# Altium schematic sheet Synth.SchDoc
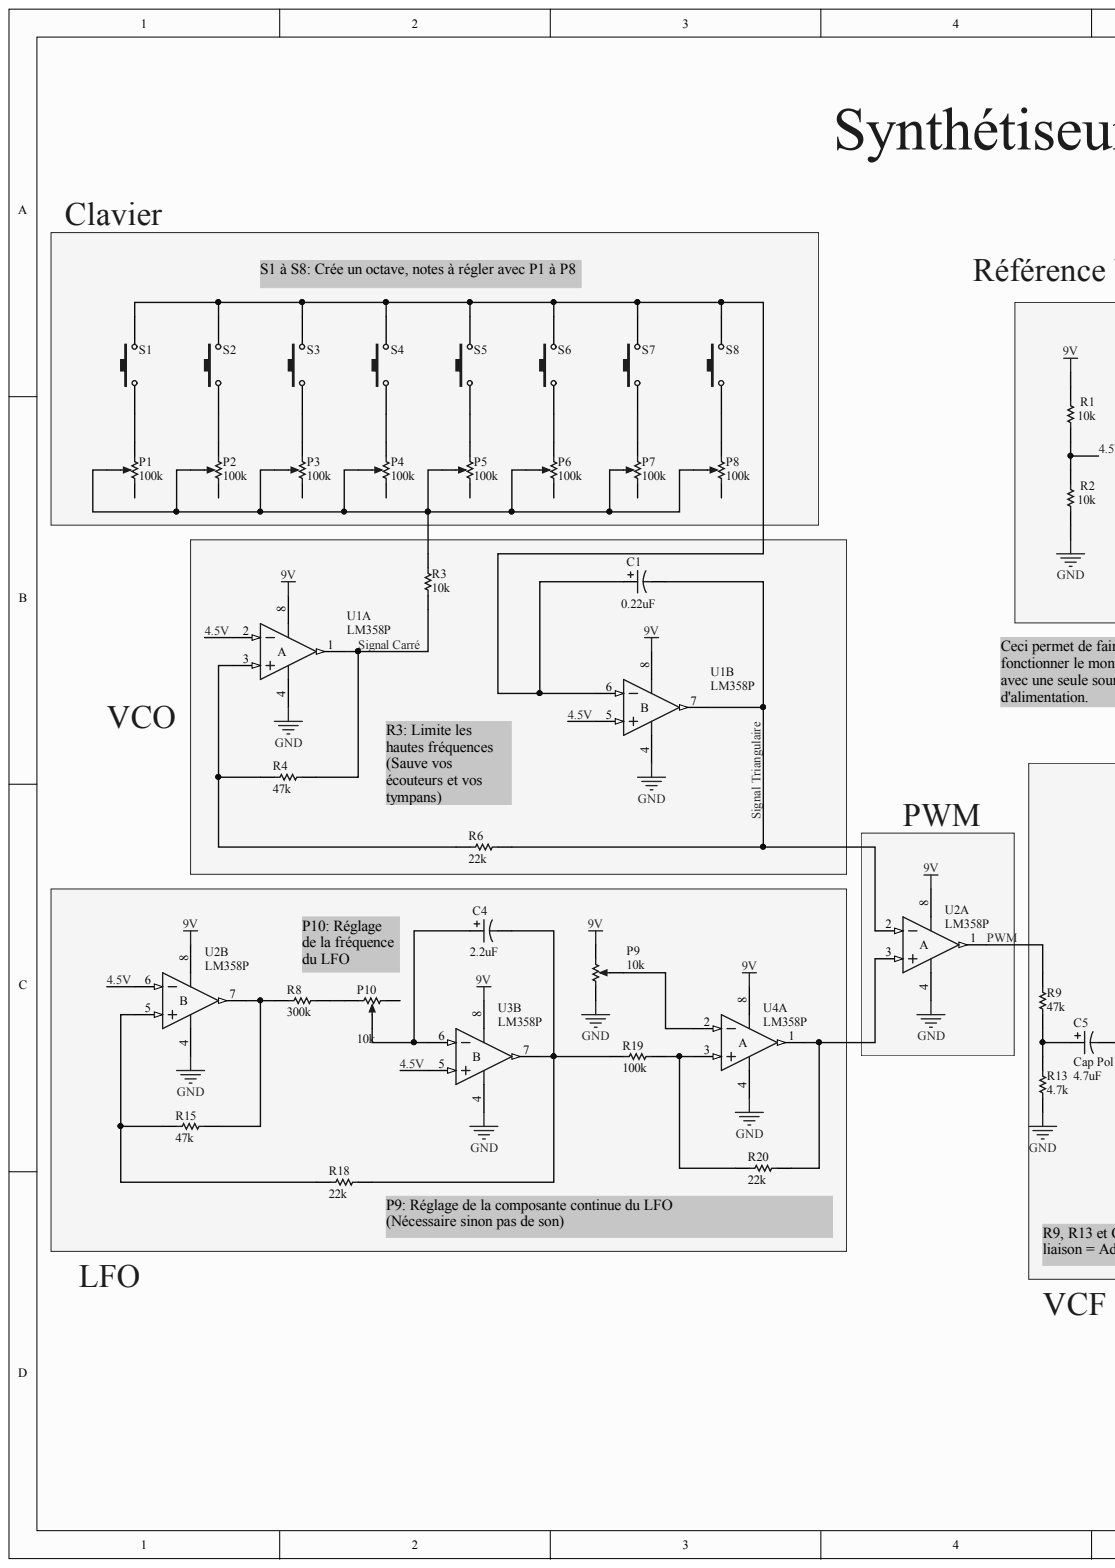
[diagram p0: Synth.SchDoc, left]
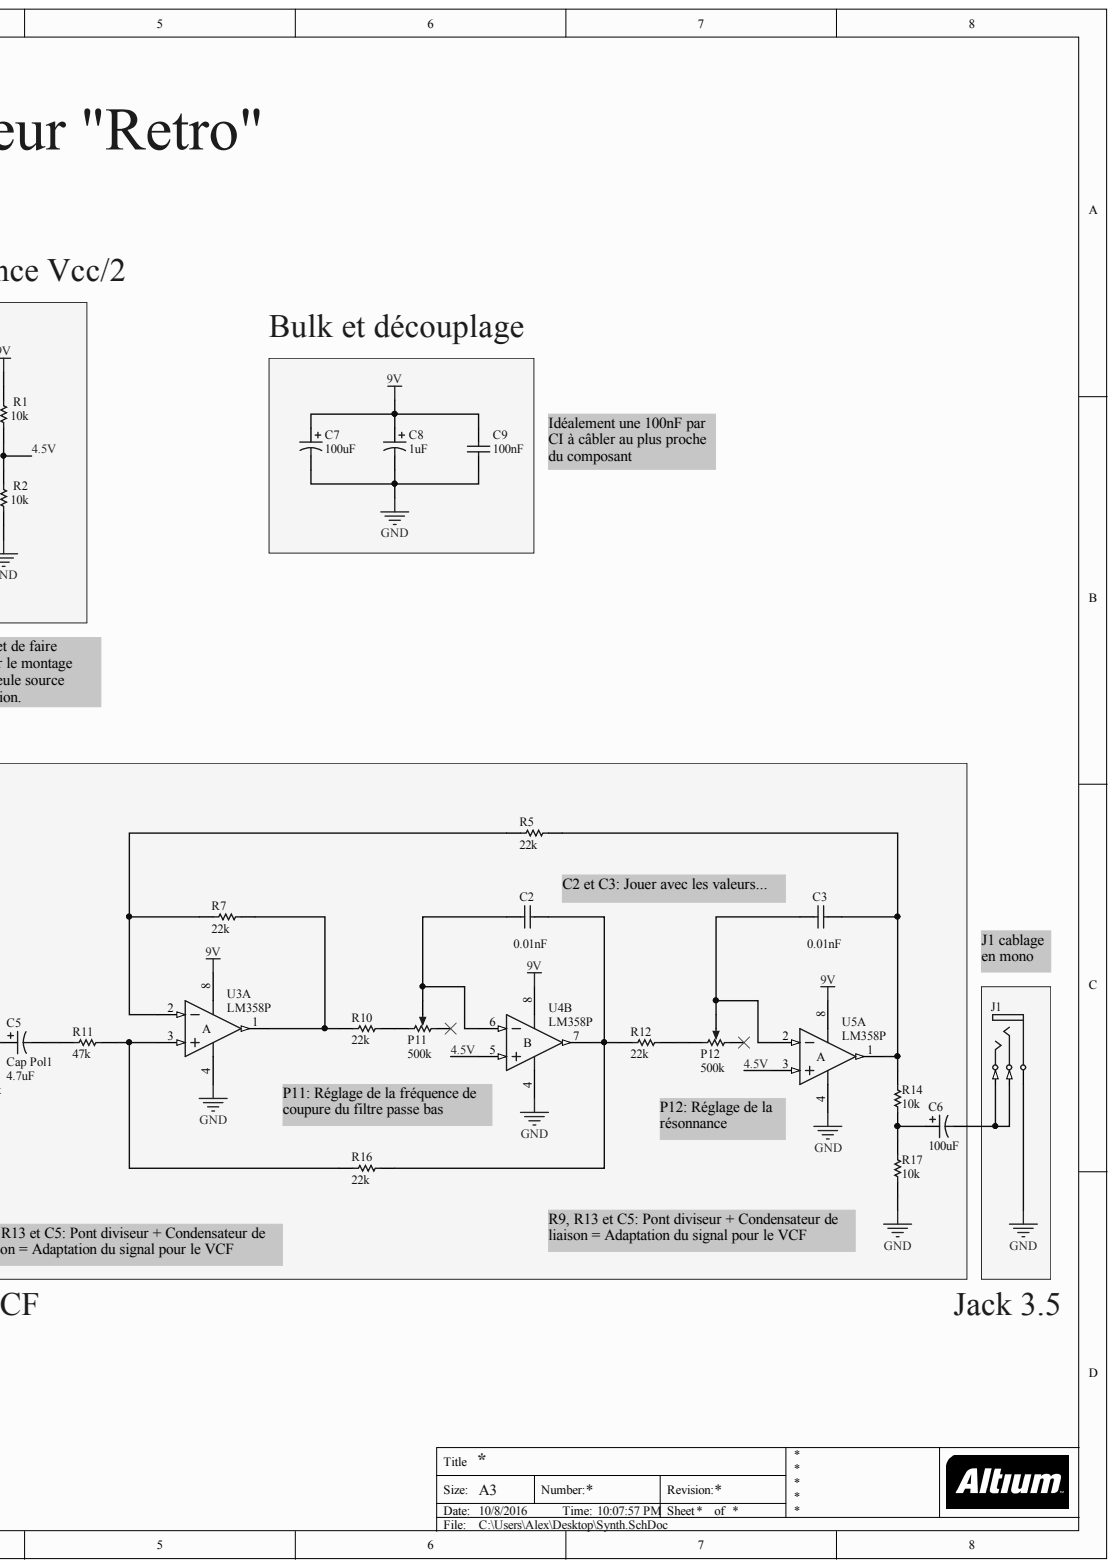
[diagram p1: Synth.SchDoc, right]

## Parts
C1  value 0.22uF  [RB7.6-15]  (hidden comment: Cap Pol1)
C2  value 0.01nF  [RAD-0.3]  (hidden comment: Cap)
C3  value 0.01nF  [RAD-0.3]  (hidden comment: Cap)
C4  value 2.2uF  [RB7.6-15]  (hidden comment: Cap Pol1)
C5  Cap Pol1  value 4.7uF  [RB7.6-15]
C6  value 100uF  [RB7.6-15]  (hidden comment: Cap Pol1)
C7  value 100uF  [RB7.6-15]  (hidden comment: Cap Pol1)
C8  value 1uF  [RB7.6-15]  (hidden comment: Cap Pol1)
C9  value 100nF  [RAD-0.3]  (hidden comment: Cap)
J1  Phonejack3  [JACK/6-V3]  (value not drawn)
P1  value 100k  [VR5]  (hidden comment: RPot)
P2  value 100k  [VR5]  (hidden comment: RPot)
P3  value 100k  [VR5]  (hidden comment: RPot)
P4  value 100k  [VR5]  (hidden comment: RPot)
P5  value 100k  [VR5]  (hidden comment: RPot)
P6  value 100k  [VR5]  (hidden comment: RPot)
P7  value 100k  [VR5]  (hidden comment: RPot)
P8  value 100k  [VR5]  (hidden comment: RPot)
P9  value 10k  [VR5]  (hidden comment: RPot)
P10  value 10k  [VR5]  (hidden comment: RPot)
P11  value 500k  [VR5]  (hidden comment: RPot)
P12  value 500k  [VR5]  (hidden comment: RPot)
R1  value 10k  [AXIAL-0.3]  (hidden comment: Res1)
R2  value 10k  [AXIAL-0.3]  (hidden comment: Res1)
R3  value 10k  [AXIAL-0.3]  (hidden comment: Res1)
R4  value 47k  [AXIAL-0.3]  (hidden comment: Res1)
R5  value 22k  [AXIAL-0.3]  (hidden comment: Res1)
R6  value 22k  [AXIAL-0.3]  (hidden comment: Res1)
R7  value 22k  [AXIAL-0.3]  (hidden comment: Res1)
R8  value 300k  [AXIAL-0.3]  (hidden comment: Res1)
R9  value 47k  [AXIAL-0.3]  (hidden comment: Res1)
R10  value 22k  [AXIAL-0.3]  (hidden comment: Res1)
R11  value 47k  [AXIAL-0.3]  (hidden comment: Res1)
R12  value 22k  [AXIAL-0.3]  (hidden comment: Res1)
R13  value 4.7k  [AXIAL-0.3]  (hidden comment: Res1)
R14  value 10k  [AXIAL-0.3]  (hidden comment: Res1)
R15  value 47k  [AXIAL-0.3]  (hidden comment: Res1)
R16  value 22k  [AXIAL-0.3]  (hidden comment: Res1)
R17  value 10k  [AXIAL-0.3]  (hidden comment: Res1)
R18  value 22k  [AXIAL-0.3]  (hidden comment: Res1)
R19  value 100k  [AXIAL-0.3]  (hidden comment: Res1)
R20  value 22k  [AXIAL-0.3]  (hidden comment: Res1)
S1  SW-PB  [SPST-2]  (value not drawn)
S2  SW-PB  [SPST-2]  (value not drawn)
S3  SW-PB  [SPST-2]  (value not drawn)
S4  SW-PB  [SPST-2]  (value not drawn)
S5  SW-PB  [SPST-2]  (value not drawn)
S6  SW-PB  [SPST-2]  (value not drawn)
S7  SW-PB  [SPST-2]  (value not drawn)
S8  SW-PB  [SPST-2]  (value not drawn)
U1  LM358P  [P008]  (parts A,B)
U2  LM358P  [P008]  (parts A,B)
U3  LM358P  [P008]  (parts A,B)
U4  LM358P  [P008]  (parts A,B)
U5  LM358P  [P008]  (part A)

## Nets
9V: C7.1 C8.1 C9.2 P9.2 R1.2 U1.8 U2.8 U3.8 U4.8 U5.8
GND: C7.2 C8.2 C9.1 J1.5 P9.1 R2.1 R13.2 R17.2 U1.4 U2.4 U3.4 U4.4 U5.4
4.5V: R1.1 R2.2 U1.2 U1.5 U2.6 U3.5 U4.5 U5.3
PWM: R9.1 U2.1
SIGNAL CARRé: R3.2 R4.1 U1.1
SIGNAL TRIANGULAIRE: C1.2 R6.1 U1.7 U2.2
NetC1_1: C1.1 S1.2 S2.2 S3.2 S4.2 S5.2 S6.2 S7.2 S8.2 U1.6
NetC2_1: C2.1 P11.3 U4.6
NetC2_2: C2.2 R12.1 R16.2 U4.7
NetC3_1: C3.1 P12.3 U5.2
NetC3_2: C3.2 R5.2 R14.1 U5.1
NetC4_1: C4.1 P10.3 U3.6
NetC4_2: C4.2 R18.1 R19.2 U3.7
NetC5_1: C5.1 R9.2 R13.1
NetC5_2: C5.2 R11.1
NetC6_1: C6.1 R14.2 R17.1
NetC6_2: C6.2 J1.1 J1.3
NetP1_1: P1.1 S1.1
NetP1_3: P1.3 P2.3 P3.3 P4.3 P5.3 P6.3 P7.3 P8.3 R3.1
NetP2_1: P2.1 S2.1
NetP3_1: P3.1 S3.1
NetP4_1: P4.1 S4.1
NetP5_1: P5.1 S5.1
NetP6_1: P6.1 S6.1
NetP7_1: P7.1 S7.1
NetP8_1: P8.1 S8.1
NetP9_3: P9.3 U4.2
NetP10_1: P10.1 R8.1
NetP11_2: P11.2 R10.2
NetP12_2: P12.2 R12.2
NetR4_2: R4.2 R6.2 U1.3
NetR5_1: R5.1 R7.1 U3.2
NetR7_2: R7.2 R10.1 U3.1
NetR8_2: R8.2 R15.1 U2.7
NetR11_2: R11.2 R16.1 U3.3
NetR15_2: R15.2 R18.2 U2.5
NetR19_1: R19.1 R20.2 U4.3
NetR20_1: R20.1 U2.3 U4.1

Not connected: P1 2; P2 2; P3 2; P4 2; P5 2; P6 2; P7 2; P8 2; P10 2; P11 1; P12 1

## Library data of the parts
C1 C4 C6-C8 = Cap Pol1: Polarized Capacitor (Radial); Component Kind: Standard; LatestRevisionDate: 17-Jul-2002; LatestRevisionNote: Re-released for DXP Platform.; Library Name: Miscellaneous Devices.IntLib; PackageDescription: Polarized Capacitor (Radial); 2 Leads; PackageReference: RB7.6-15; Published: 8-Jun-2000; Signal Integrity: Cap Pol; Simulation: CAP; Publisher: Altium Limited
C2 C3 C9 = Cap: Capacitor; Component Kind: Standard; LatestRevisionDate: 17-Jul-2002; LatestRevisionNote: Re-released for DXP Platform.; Library Name: Miscellaneous Devices.IntLib; PackageDescription: Radial Cap, Thru-Hole; 2 Leads; 0.3 in Pin Spacing; PackageReference: RAD-0.3; Published: 8-Jun-2000; Signal Integrity: Cap; Simulation: CAP; Publisher: Altium Limited
C5 = Cap Pol1: Polarized Capacitor (Radial); Value: 4.7uF; Component Kind: Standard; LatestRevisionDate: 17-Jul-2002; LatestRevisionNote: Re-released for DXP Platform.; Library Name: Miscellaneous Devices.IntLib; PackageDescription: Polarized Capacitor (Radial); 2 Leads; PackageReference: RB7.6-15; Published: 8-Jun-2000; Signal Integrity: Cap Pol; Simulation: CAP; Publisher: Altium Limited
J1 = Phonejack3: Jack Socket, 1/4" [6.5mm], Thru-Hole, Vertical, 3-Conductor Open Circuit (Non-Normalling); DrillAndSlotSize: Corresponds to nominal value.; PackageDocument: 2003; PCB_Layout: Complies with manufacturer's recommendation.; Component Kind: Standard; ComponentLink1Description: Manufacturer Link; ComponentLink1URL: http://www.cliffuk.co.uk/; DatasheetDocument: 2003; LatestRevisionDate: 15-Jul-2004; LatestRevisionNote: Component Replaced.; Library Name: Miscellaneous Connectors.IntLib; PackageReference: S1VS_SSS; Published: 15-Jul-2004; Signal Integrity: JACK Socket; Publisher: Altium Limited
P1-P12 = RPot: Potentiometer; Set Position: 0.5; Sim Note: Enter the Resistance value and the set point into parameter fields.; Component Kind: Standard; LatestRevisionDate: 17-Jul-2002; LatestRevisionNote: Re-released for DXP Platform.; Library Name: Miscellaneous Devices.IntLib; PackageDescription: Variable Resistor, Thru-Hole; 3 Leads; PackageReference: VR5; Published: 8-Jun-2000; Simulation: POT; Publisher: Altium Limited
R1-R20 = Res1: Resistor; Component Kind: Standard; LatestRevisionDate: 17-Jul-2002; LatestRevisionNote: Re-released for DXP Platform.; Library Name: Miscellaneous Devices.IntLib; PackageDescription: Axial Device, Thru-Hole; 2 Leads; 0.3 in Pin Spacing; PackageReference: AXIAL-0.3; Published: 8-Jun-2000; Signal Integrity: Res; Simulation: RESISTOR; Publisher: Altium Limited
S1-S8 = SW-PB: Switch; Component Kind: Standard; LatestRevisionDate: 17-Jul-2002; LatestRevisionNote: Re-released for DXP Platform.; Library Name: Miscellaneous Devices.IntLib; PackageDescription: Switch; 2 Leads; PackageReference: SPST-2; Published: 24-Mar-1999; Publisher: Altium Limited
U1-U5 = LM358P: Dual Operational Amplifier; Code_JEDEC: MO-001-AN; Component Kind: Standard; ComponentLink1Description: Manufacturer Link; ComponentLink1URL: http://www.ti.com/; ComponentLink2Description: Datasheet; ComponentLink2URL: http://www-s.ti.com/sc/ds/lm358.pdf; DatasheetVersion: Jul-1998; LatestRevisionDate: 04-Mar-2005; LatestRevisionNote: Stylized 3D Model Added.; Library Name: TI Operational Amplifier.IntLib; PackageDescription: DIP; 8 Leads; Row Spacing 7.62 mm; Pitch 2.54 mm; PackageReference: P008; PackageVersion: Jun-1999; PCB3D: P008; Published: 16-Jan-2001; Simulation: LM358; Publisher: Altium Limited
